# Altium schematic sheet 10-Power.SchDoc
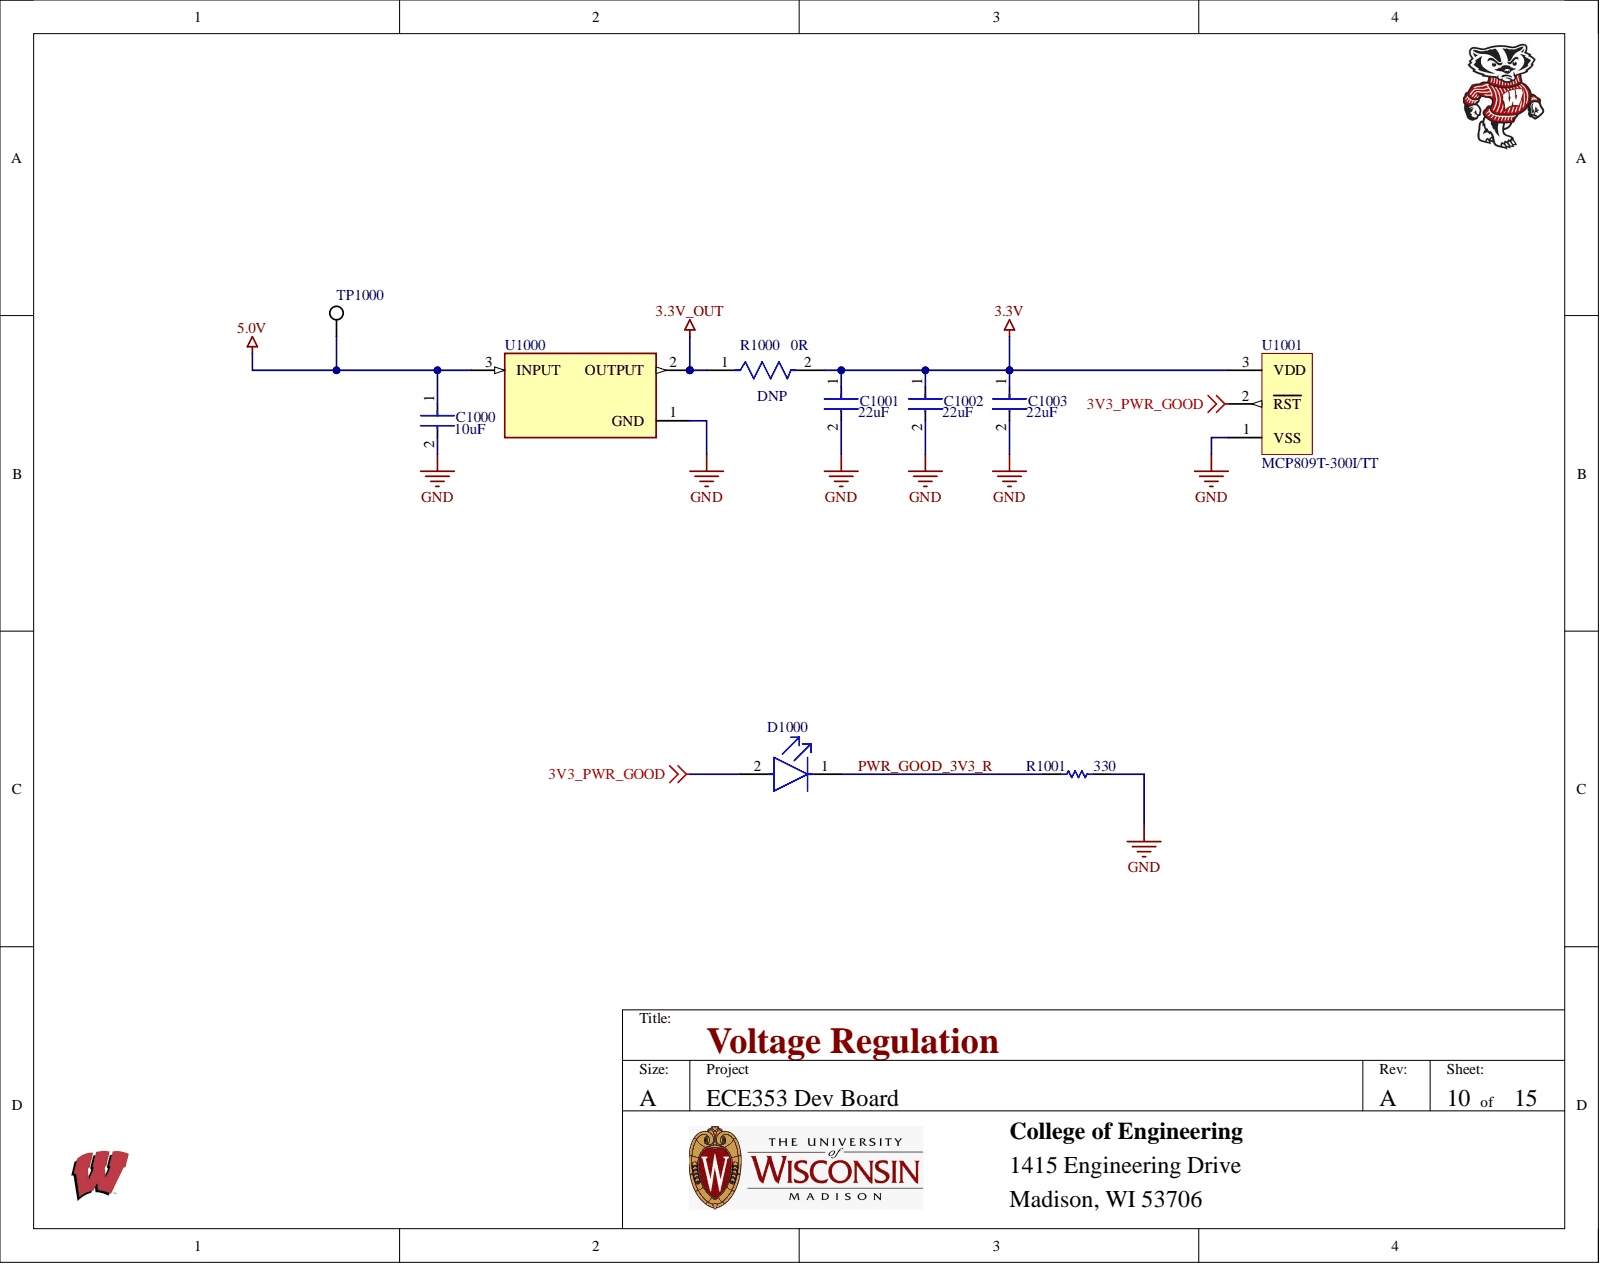
[diagram p0: 10-Power.SchDoc]

## Parts
C1000  value 10uF  Capacitance: 10uF  [FP-C0805C-DG-MFG]  (hidden comment: C0805C106K8PACTU)
C1001  Capacitance: 22uF  [FP-GRM21B-0_15-MFG]  (hidden comment: GRM21BR60J226ME39L)
C1002  Capacitance: 22uF  [FP-GRM21B-0_15-MFG]  (hidden comment: GRM21BR60J226ME39L)
C1003  Capacitance: 22uF  [FP-GRM21B-0_15-MFG]  (hidden comment: GRM21BR60J226ME39L)
D1000  APHCM2012CGCK-F01  [FP-APHCM2012CGCK-F01-MFG]  (value not drawn)
R1000  DNP  Resistance: 0R  [FP-RC1206-0_65-IPC_C]  (no BOM)
R1001  RC0805FR-07330RL  [RESC2013X60X35LL10T20]  (value not drawn)
TP1000  5V  [KSTN-5019_V]  (value not drawn)  (no BOM)
U1000  AZ1117CR-3.3TRG1  [FP-SOT89-MFG]  (value not drawn)
U1001  MCP809T-300I/TT  [SOT-23-TT3_L]

## Nets
3.3V: C1001.1 C1002.1 C1003.1 R1000.2 U1001.3
3.3V_OUT: R1000.1 U1000.2
3V3_PWR_GOOD: D1000.2 U1001.2
5.0V: C1000.1 TP1000.1 U1000.3
GND: C1000.2 C1001.2 C1002.2 C1003.2 R1001.2 U1000.1 U1001.1
PWR_GOOD_3V3_R: D1000.1 R1001.1

## Library data of the parts
C1000 = CMP-2007-03243-2: CAP CER 10UF 10V X5R 0805; 3DS Part Number: 220-00001; Manufacturer: Kemet; Dielectric Material: Ceramic; Dissipation Factor: 10%; Temperature Coefficient: 15%; Automotive: No; Case Code (Imperial): 0805; Case Code (Metric): 2012; Case/Package: 0805; Category: Cap; Component Kind: Standard; ComponentLink2Description: Datasheet; ComponentLink2URL: https://content.kemet.com/datasheets/KEM_C1006_X5R_SMD.pdf; Construction: Flat; Contact Plating: Tin,Matte; Depth: 1.25mm; Device Class L1: Passive Components; Device Class L2: Capacitors; Device Class L3: Ceramic Capacitors; Dielectric: X5R; Digikey Description: CAP CER 10UF 10V X5R 0805; Height: 1.4mm; Ipc Land Pattern Name: CAPC200125X125; Lead Free: Yes; Length: 2mm; Library Name: Altium Content Vault; Manufacturer 1: KEMET; Manufacturer Part Number: C0805C106K8PACTU; Manufacturer Part Number 1: C0805C106K8PACTU; Material: Ceramic; Max Operating Temperature: 85°C; Min Operating Temperature: -55°C; Mount: Surface Mount; Mouser Description: CAP CER 10UF 10V X5R 0805; Number of Pins: 2; Octopart: https://octopart.com/c0805c106k8pactu-kemet-98697; Package: C0805C-DG; Package Quantity: 1; Packaging: Tape and Reel; Pricing 1: 1=0.14000, 10=0.10300, 50=0.05840, 100=0.04830, 500=0.03388, 1000=0.02805 (USD); Radiation Hardening: No; Reach SVHC Compliant: Yes; RoHS: TRUE; RoHS Compliant: Yes; Series: C; Stock 1: 8391476; Supplier 1: Digi-Key; Supplier Part Number 1: 399-C0805C106K8PAC7800CT-ND; Temperature: -55°C to +85°C; Temperature Characteristic: X5R; Temperature Range High: +85°C; Temperature Range Low: -55°C; Termination: SMD/SMT; Thickness: 0.055inch; Tolerance: 10%; Voltage: 10V; Voltage Rating: 10V; Voltage Rating (DC): 10V; Width: 0.049inch
C1001-C1003 = CMP-2007-03385-2: Chip Multilayer Ceramic Capacitors for General Purpose, 0805, 22uF, X5R, 15%, 20%, 6.3V; Manufacturer 1: Murata; Case Code (Imperial): 0805; Case Code (Metric): 2012; Case/Package: 0805; Category: Ceramic Capacitors; Component Kind: Standard; Construction: Flat; Depth: 1.25mm; Dielectric: X5R; Height: 1.25mm; Lead Free: Lead Free; Length: 2mm; Library Name: Altium Content Vault; Manufacturer Part Number 1: GRM21BR60J226ME39L; Material: Ceramic; Max Operating Temperature: 85°C; Min Operating Temperature: -55°C; Mount: Surface Mount; Package Quantity: 1; Packaging: Tape and Reel; Pricing 1: 1=0.37000, 10=0.25600, 50=0.17940, 100=0.15380, 500=0.11532, 1000=0.09739 (USD); Radiation Hardening: No; REACH SVHC: No SVHC; Reach SVHC Compliant: Yes; RoHS: Yes; RoHS Compliant: Yes; Series: GRM; Stock 1: 2850512; Supplier 1: Digi-Key; Supplier Part Number 1: 490-1719-1-ND; Termination: SMD/SMT; Thickness: 0.053inch; Tolerance: 20%; Voltage: 6.3V; Voltage Rating: 6.3V; Voltage Rating (DC): 6.3V; Width: 0.049inch
D1000 = CMP-44529-000062-1: LED GREEN CLEAR CHIP SMD; Manufacturer 1: Kingbright; Dominant Wavelength: 570nm; Forward Current: 20mA; Forward Voltage: 2.1V; Luminous Intensity: 40mcd; Number of LEDs: 1; Viewing Angle: 110°; Case Code (Imperial): 0805; Case Code (Metric): 2012; Case/Package: 0805; Category: LEDs; Color: Green; Component Kind: Standard; ComponentLink1Description: https://datasheet.ciiva.com/2113/aphcm2012cgck-f01-2113927.pdf?src-supplier=Digi-Key; ComponentLink1URL: https://datasheet.ciiva.com/2113/aphcm2012cgck-f01-2113927.pdf?src-supplier=Digi-Key; ComponentLink2Description: https://datasheet.ciiva.com/12403/aphcm2012cgck-f01-34366-12403909.pdf?src-supplier=Mouser; ComponentLink2URL: https://datasheet.ciiva.com/12403/aphcm2012cgck-f01-34366-12403909.pdf?src-supplier=Mouser; ComponentLink3Description: https://datasheet.ciiva.com/16494/aphcm2012cgck-f01-16494095.pdf?src-supplier=Future+Electronics; ComponentLink3URL: https://datasheet.ciiva.com/16494/aphcm2012cgck-f01-16494095.pdf?src-supplier=Future+Electronics; ComponentLink4Description: https://datasheet.ciiva.com/2113/aphcm2012cgck-f01-2113928.pdf?src-supplier=Digi-Key; ComponentLink4URL: https://datasheet.ciiva.com/2113/aphcm2012cgck-f01-2113928.pdf?src-supplier=Digi-Key; ComponentLink5Description: https://datasheet.ciiva.com/2113/aphcm2012cgck-f01-2113929.pdf?src-supplier=Digi-Key; ComponentLink5URL: https://datasheet.ciiva.com/2113/aphcm2012cgck-f01-2113929.pdf?src-supplier=Digi-Key; Height: 0.4mm; Illumination Color: Green; Lead Free: Lead Free; Length: 2mm; Lens Color: Clear; Lens Style: Clear; Library Name: Altium Content Vault; Manufacturer Part Number 1: APHCM2012CGCK-F01; Max Operating Temperature: 85°C; Min Operating Temperature: -40°C; Mount: Surface Mount; Number of Pins: 2; Package Quantity: 1; Packaging: Tape and Reel; Pricing 1: 1=0.34000, 10=0.26900, 100=0.12090, 500=0.10920, 1000=0.08970 (USD); RoHS: Yes; RoHS Compliant: Yes; Series: APHCM; Stock 1: 63910; Supplier 1: Digi-Key; Supplier Part Number 1: 754-1094-1-ND; Test Current: 20mA; Wavelength: 570nm; Width: 1.25mm
R1000 = CMP-2003-03534-2: RES SMD 0 OHM JUMPER 1/4W 1206; Manufacturer 1: Yageo; Manufacturer Part Number 1: RC1206FR-070RL; Max Power Dissipation: 250mW; Temperature Coefficient: 200ppm/°C; Tolerance: 1%; Case Code (Imperial): 1206; Case Code (Metric): 3216; Case/Package: 1206; Category: Chip SMD Resistors; ComponentLink1Description: https://datasheet.ciiva.com/17032/pyu-rc1206-51-rohs-l-4-17032972.pdf?src-supplier=Future+Electronics; ComponentLink1URL: https://datasheet.ciiva.com/17032/pyu-rc1206-51-rohs-l-4-17032972.pdf?src-supplier=Future+Electronics; Contact Plating: Tin; Features: Moisture Resistant; Height: 0.026inch; Lead Free: Lead Free; Length: 0.122inch; Library Name: Altium Content Vault; Max Operating Temperature: 155°C; Min Operating Temperature: -55°C; Mount: Surface Mount; Number of Terminations: 2; Packaging: Tape and Reel; Power Rating: 250mW; Pricing 1: 1=0.1, 10=0.058, 25=0.0424, 50=0.0322, 100=0.0237, 250=0.01808, 500=0.01448, 1000=0.01065 (USD); Radiation Hardening: No; Resistor Type: Thick Film; RoHS: Yes; RoHS Compliant: Yes; Series: RC; Size Code: 1206; Stock 1: 498794; Supplier 1: Digi-Key; Supplier Part Number 1: YAG3367CT-ND; Width: 0.063inch
R1001 = CMP-2000-06960-1: Chip Resistor, 330 Ohm, +/- 1%, 125 mW, -55 to 155 degC, 0805 (2012 Metric), RoHS, Tape and Reel; Manufacturer: Yageo; Max Power Dissipation: 125mW; Power Rating: 125mW(1/8W); Resistance: 330; Temperature Coefficient: 100PPM/C; Voltage Rating: 150V; Case Code (Imperial): 0805; Case Code (Metric): 2012; Case Code - in: 0805; Case Code - mm: 2012; Case/Package: 0805; Category: Chip SMD Resistors; Component Kind: Standard; ComponentLink1Description: https://datasheet.ciiva.com/2457/pyu-r-int-thick-7-2457015.pdf?src-supplier=Digi-Key; ComponentLink1URL: https://datasheet.ciiva.com/2457/pyu-r-int-thick-7-2457015.pdf?src-supplier=Digi-Key; ComponentLink2Description: https://datasheet.ciiva.com/2457/pyu-r-int-thick-7-2457016.pdf?src-supplier=Digi-Key; ComponentLink2URL: https://datasheet.ciiva.com/2457/pyu-r-int-thick-7-2457016.pdf?src-supplier=Digi-Key; ComponentLink3Description: https://datasheet.ciiva.com/2457/pyu-r-int-thick-7-2457014.pdf?src-supplier=Digi-Key; ComponentLink3URL: https://datasheet.ciiva.com/2457/pyu-r-int-thick-7-2457014.pdf?src-supplier=Digi-Key; ComponentLink4Description: https://datasheet.ciiva.com/12667/rc0805-12667925.pdf?src-supplier=Mouser; ComponentLink4URL: https://datasheet.ciiva.com/12667/rc0805-12667925.pdf?src-supplier=Mouser; ComponentLink5Description: https://datasheet.ciiva.com/7941/444164-7941768.pdf?src-supplier=Element14; ComponentLink5URL: https://datasheet.ciiva.com/7941/444164-7941768.pdf?src-supplier=Element14; ComponentLink6Description: https://datasheet.ciiva.com/7941/444164-7941769.pdf?src-supplier=Element14; ComponentLink6URL: https://datasheet.ciiva.com/7941/444164-7941769.pdf?src-supplier=Element14; ComponentLink7Description: https://datasheet.ciiva.com/16740/rc0805-16740975.pdf?src-supplier=Future+Electronics; ComponentLink7URL: https://datasheet.ciiva.com/16740/rc0805-16740975.pdf?src-supplier=Future+Electronics; Construction: Rectangular; Datasheet URL: http://www.yageo.com/documents/recent/PYu-RC_Group_51_RoHS_L_7.pdf; Datasheet Version: Rev. 7, 05/2017; Depth: 1.25mm; Features: Moisture Resistant; Height: 0.024inch; Lead Free: Lead Free; Length: 0.079inch; Library Name: Altium Content Vault; Manufacturer 1: Yageo; Manufacturer Part Number 1: RC0805FR-07330RL; Manufacturer URL: http://www.yageo.com/; Max Operating Temperature: 155°C; Military Standard: Not; Min Operating Temperature: -55°C; Mounting Technology: SurfaceMount; Number of Terminations: 2; Operating Temperature Range: -55Cto+155C; Package Description: 2-Pin Surface Mounted Device, Body 2 x 1.25 mm; Package Quantity: 5000; Package Reference: 0805; Packaging: Reel; Pricing 1: 1=0.10000, 10=0.03700, 100=0.01510, 1000=0.00680, 2500=0.00590 (USD); Product: ThickFilmResistorsGeneralPurpose; Resistance Tolerance: 1%; Resistor Type: Thick Film; RoHS: Yes; RoHS Compliant: Yes; Series: RC; Size Code: 0805; Stock 1: 1297787; Supplier 1: Digi-Key; Supplier Part Number 1: 311-330CRCT-ND; Termination: SMD/SMT; Termination Style: SMD/SMT; Tolerance: 1%; Width: 0.049inch
TP1000 = CMP-1672-00008-1: Test Point, 1 Position SMD, RoHS, Tape and Reel; Manufacturer 1: Keystone Electronics; Manufacturer Part Number 1: 5019; Body Material: Bronze; Plating: Silver; Category: Connectors; ComponentLink1Description: Manufacturer URL; ComponentLink1URL: http://www.keyelco.com/; ComponentLink2Description: Datasheet; ComponentLink2URL: http://www.keyelco.com/product-pdf.cfm?p=1357; ComponentLink3Description: https://datasheet.ciiva.com/16885/70182998-16885945.pdf?src-supplier=Allied+Electronics; ComponentLink3URL: https://datasheet.ciiva.com/16885/70182998-16885945.pdf?src-supplier=Allied+Electronics; ComponentLink4Description: https://datasheet.ciiva.com/14848/m60-2p61-14848437.pdf?src-supplier=Digi-Key; ComponentLink4URL: https://datasheet.ciiva.com/14848/m60-2p61-14848437.pdf?src-supplier=Digi-Key; ComponentLink5Description: https://datasheet.ciiva.com/14848/m60-2p61-14848438.pdf?src-supplier=Digi-Key; ComponentLink5URL: https://datasheet.ciiva.com/14848/m60-2p61-14848438.pdf?src-supplier=Digi-Key; ComponentLink6Description: https://datasheet.ciiva.com/14848/m60-2p61-14848439.pdf?src-supplier=Digi-Key; ComponentLink6URL: https://datasheet.ciiva.com/14848/m60-2p61-14848439.pdf?src-supplier=Digi-Key; ComponentLink7Description: https://datasheet.ciiva.com/16318/m60-2p61-16318395.pdf?src-supplier=Future+Electronics; ComponentLink7URL: https://datasheet.ciiva.com/16318/m60-2p61-16318395.pdf?src-supplier=Future+Electronics; Contact Material: Bronze; Contact Plating: Silver; DatasheetVersion: 03/2014; Height: 0.09inch; Lead Free: Lead Free; Library Name: Altium Content Vault; Material: Bronze; Mount: Surface Mount; Mounting Technology: Surface Mount; Number of Pins: 1; Package Quantity: 1000; PackageDescription: 1-Pin Surface Mount Device, Body 3.81 x 2.03 mm; PackageReference: 5019; Packaging: Tape and Reel; Pins: 1; Pricing 1: 1=0.34000, 25=0.27360, 100=0.22340, 250=0.20064, 500=0.19152 (USD); Reach SVHC Compliant: Yes; RoHS: Yes; RoHS Compliant: Yes; RoHSCompliant: True; Series: 5019; Stock 1: 952992; Supplier 1: Digi-Key; Supplier Part Number 1: 36-5019CT-ND; Termination: SMD/SMT
U1000 = CMP-12756-000007-1: IC REG LINEAR 3.3V 800MA SOT89; Manufacturer: Diodes Inc.; Manufacturer 1: Diodes; Accuracy: 1%; Dropout Voltage: 1.2V; Max Input Voltage: 15V; Max Junction Temp: +125°C; Max Output Current: 1.35A; Min Current Limit: 1A; Nominal Supply Current: 4mA; Number Of Outputs: 1; Output Type: Fixed; Polarity: Positive; Power Supply Rejection Ratio (PSRR): 70dB; Reference Voltage: 1.262V; Usage: DNP; Accuracy Percentage: 1%; Automotive: No; Case/Package: SOT-89; Category: IC; Component Kind: Standard; ComponentLink1Description: https://datasheet.ciiva.com/29568/az1117c-29568862.pdf?src-supplier=Digi-Key; ComponentLink1URL: https://datasheet.ciiva.com/29568/az1117c-29568862.pdf?src-supplier=Digi-Key; ComponentLink2Description: Datasheet; ComponentLink2URL: https://www.diodes.com/assets/Datasheets/AZ1117C.pdf; ComponentLink3Description: https://datasheet.ciiva.com/30016/az1117c-30016678.pdf?src-supplier=Avnet; ComponentLink3URL: https://datasheet.ciiva.com/30016/az1117c-30016678.pdf?src-supplier=Avnet; Device Class L1: Integrated Circuits (ICs); Device Class L2: Power Management ICs; Device Class L3: Voltage Regulators - Linear; Digikey Description: IC REG LINEAR 3.3V 800MA SOT89; Footprint Url: https://www.diodes.com/assets/Package-Files/SOT89.pdf; Height: 1.6mm; Lead Free: Yes; Length: 4.6mm; Library Name: Altium Content Vault; Manufacturer Part Number: AZ1117CR-3.3TRG1; Manufacturer Part Number 1: AZ1117CR-3.3TRG1; Max Operating Temperature: 125°C; Max Supply Voltage: 15V; Min Operating Temperature: -20°C; Mount: Surface Mount; Mouser Description: DiodesZetex AZ1117CR-3.3TRG1, LDO Regulator, 800mA, 3.3 V, ±1% 3-Pin, SOT-89; Number of Pins: 3; Number of Regulators: 1; Octopart: https://octopart.com/az1117cr-3.3trg1-diodes+inc.-30954805; Output Current: 1.35A; Output Voltage: 3.3V; Package: SOT89; Package Quantity: 1000; Packaging: Tape and Reel; Pricing 1: 1=0.44000, 10=0.33300, 25=0.30040, 100=0.20740, 250=0.17468, 500=0.14194 (USD); Quiescent Current: 4mA; RoHS: TRUE; Stock 1: 91738; Supplier 1: Digi-Key; Supplier Part Number 1: AZ1117CR-3.3TRG1DICT-ND; Temperature: -20°C to +125°C; Temperature Range High: +125°C; Temperature Range Low: -20°C; Weight: 0.004603oz; Width: 2.6mm
U1001 = CMP-0138-00368-1: Microcontroller Supervisory Circuit with Push-Pull Output, 3-Pin SOT-23, Industrial Temperature, Tape and Reel; Manufacturer 1: Microchip; Accuracy: -5%; Max Reset Threshold Voltage: 3V; Max Supply Current: 60uA; Max Supply Voltage: 5.5V; Min Reset Threshold Voltage: 2.85V; Min Supply Voltage: 1V; Number of Elements: 1; Output: TOTEM-POLE; Quiescent Current: 45uA; Reset: Active Low; Reset Timeout: 150ms; Threshold Voltage: 2.925V; Watchdog Timer: No; Case/Package: SOT-23; Category: Voltage Supervisors; Code_IPC: SOT23-3; Component Kind: Standard; ComponentLink1Description: https://datasheet.ciiva.com/41463/001085518-da-01-en-ic-supervisor-mcp809t-300i-tt-sot-23-mcp-41463202.pdf?src-supplier=Conrad; ComponentLink1URL: https://datasheet.ciiva.com/41463/001085518-da-01-en-ic-supervisor-mcp809t-300i-tt-sot-23-mcp-41463202.pdf?src-supplier=Conrad; ComponentLink2Description: https://datasheet.ciiva.com/7990/11605-7990661.pdf?src-supplier=Element14; ComponentLink2URL: https://datasheet.ciiva.com/7990/11605-7990661.pdf?src-supplier=Element14; ComponentLink3Description: https://datasheet.ciiva.com/46386/11605-46386806.pdf?src-supplier=Element14; ComponentLink3URL: https://datasheet.ciiva.com/46386/11605-46386806.pdf?src-supplier=Element14; ComponentLink4Description: https://datasheet.ciiva.com/8144/11194c-8144251.pdf?src-supplier=Avnet; ComponentLink4URL: https://datasheet.ciiva.com/8144/11194c-8144251.pdf?src-supplier=Avnet; ComponentLink5Description: https://datasheet.ciiva.com/1969/11194c-1969626.pdf?src-supplier=Digi-Key; ComponentLink5URL: https://datasheet.ciiva.com/1969/11194c-1969626.pdf?src-supplier=Digi-Key; ComponentLink6Description: https://datasheet.ciiva.com/1969/11194c-1969627.pdf?src-supplier=Digi-Key; ComponentLink6URL: https://datasheet.ciiva.com/1969/11194c-1969627.pdf?src-supplier=Digi-Key; ComponentLink7Description: https://datasheet.ciiva.com/1969/11194c-1969625.pdf?src-supplier=Digi-Key; ComponentLink7URL: https://datasheet.ciiva.com/1969/11194c-1969625.pdf?src-supplier=Digi-Key; DatasheetVersion: revC, 2001; Height: 0.04inch; Lead Free: Lead Free; Length: 0.119inch; Library Name: Altium Content Vault; Manufacturer Part Number 1: MCP809T-300I/TT; Max Operating Temperature: 85°C; Min Operating Temperature: -40°C; Mount: Surface Mount; Nominal Supply Current: 60uA; Number of Pins: 3; Number of Voltages Monitored: 1; Operating Supply Current: 60uA; Overvoltage Threshold: 3V; Package Quantity: 1; PackageDescription: 3-Lead Plastic Small Outline Transistor (TT) [SOT-23]; PackageReference: SOT-23-TT3; PackageVersion: revBB, Aug-2009; Packaging: Tape and Reel; Pricing 1: 1=0.60000, 25=0.49840, 100=0.46640 (USD); Radiation Hardening: No; Reach SVHC Compliant: Yes; RoHS: Yes; RoHS Compliant: Yes; Stock 1: 28470; Supplier 1: Digi-Key; Supplier Part Number 1: MCP809T-300I/TTCT-ND; Supply Current: 60uA; Termination: SMD/SMT; Type: Simple Reset/Power-On Reset; Undervoltage Threshold: 2.85V; Width: 0.055inch
